# Altium schematic sheet ConstantLED.SchDoc(ConstantLED)
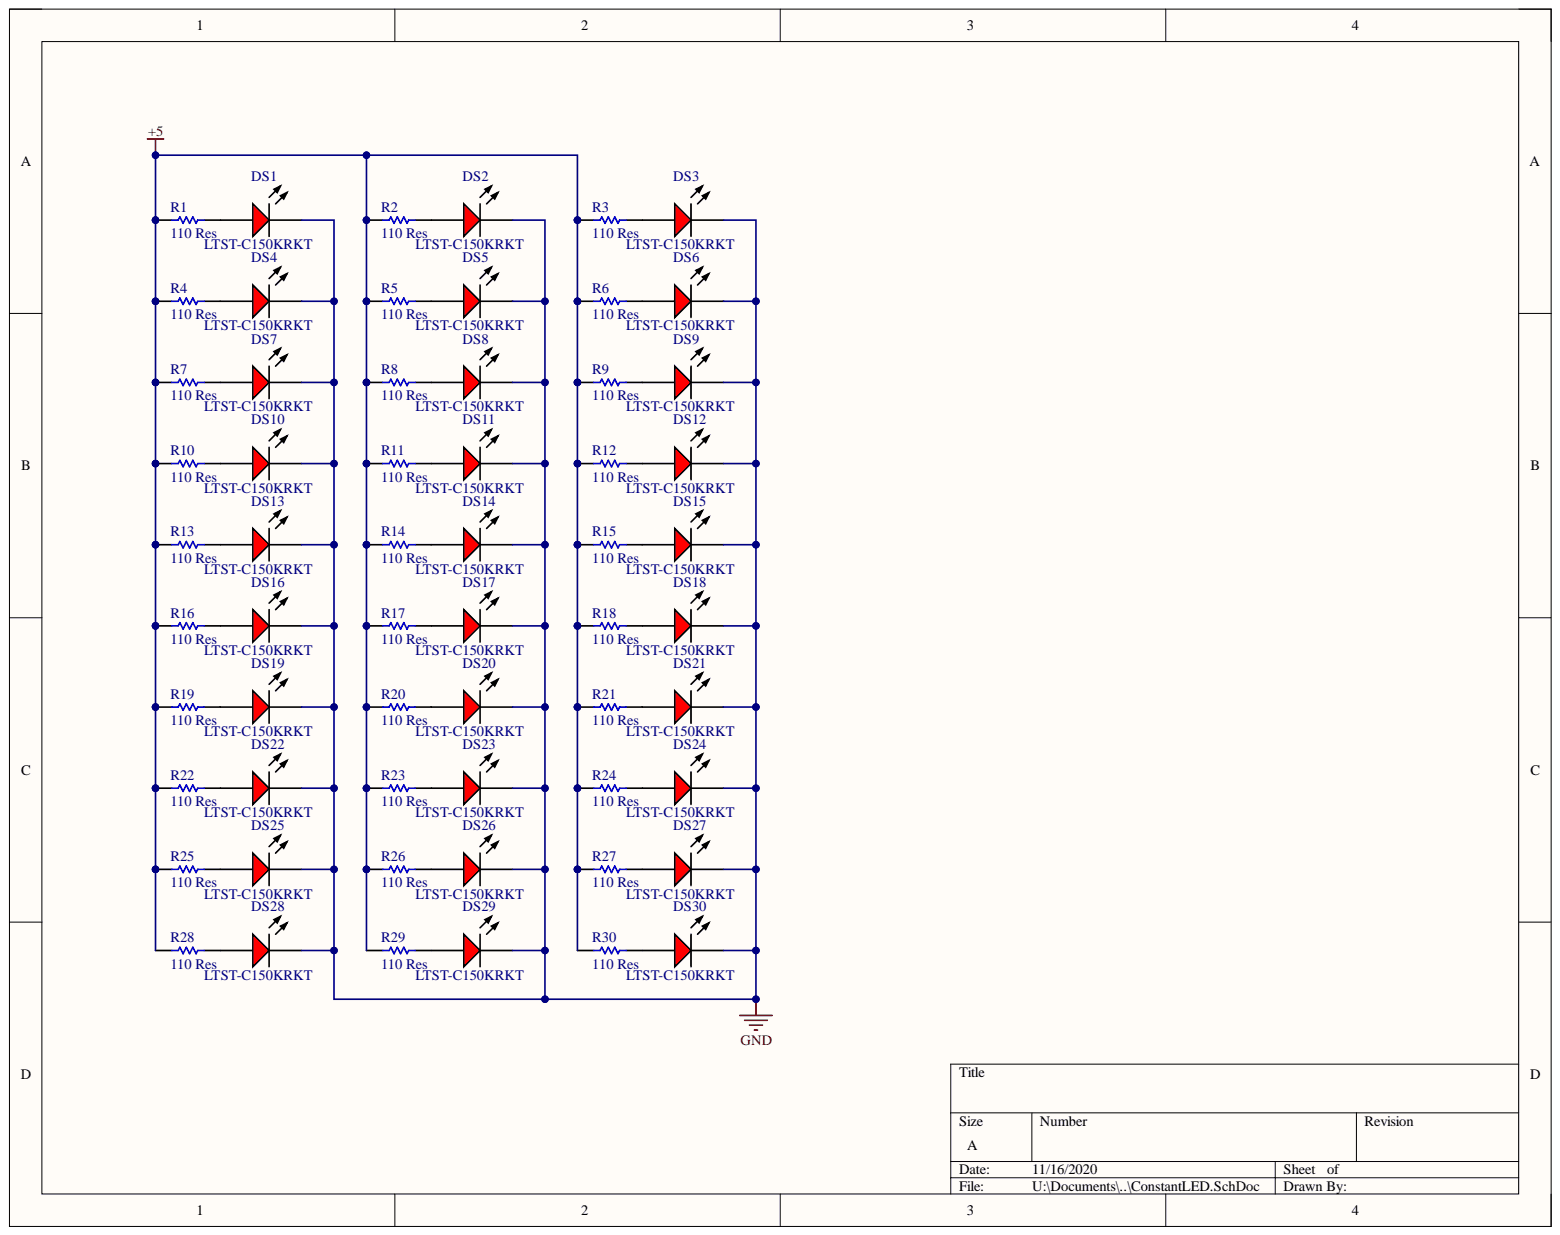
[diagram p0: ConstantLED.SchDoc]

## Parts
DS1  LTST-C150KRKT  [LTST-C150KRKT_V]
DS2  LTST-C150KRKT  [LTST-C150KRKT_V]
DS3  LTST-C150KRKT  [LTST-C150KRKT_V]
DS4  LTST-C150KRKT  [LTST-C150KRKT_V]
DS5  LTST-C150KRKT  [LTST-C150KRKT_V]
DS6  LTST-C150KRKT  [LTST-C150KRKT_V]
DS7  LTST-C150KRKT  [LTST-C150KRKT_V]
DS8  LTST-C150KRKT  [LTST-C150KRKT_V]
DS9  LTST-C150KRKT  [LTST-C150KRKT_V]
DS10  LTST-C150KRKT  [LTST-C150KRKT_V]
DS11  LTST-C150KRKT  [LTST-C150KRKT_V]
DS12  LTST-C150KRKT  [LTST-C150KRKT_V]
DS13  LTST-C150KRKT  [LTST-C150KRKT_V]
DS14  LTST-C150KRKT  [LTST-C150KRKT_V]
DS15  LTST-C150KRKT  [LTST-C150KRKT_V]
DS16  LTST-C150KRKT  [LTST-C150KRKT_V]
DS17  LTST-C150KRKT  [LTST-C150KRKT_V]
DS18  LTST-C150KRKT  [LTST-C150KRKT_V]
DS19  LTST-C150KRKT  [LTST-C150KRKT_V]
DS20  LTST-C150KRKT  [LTST-C150KRKT_V]
DS21  LTST-C150KRKT  [LTST-C150KRKT_V]
DS22  LTST-C150KRKT  [LTST-C150KRKT_V]
DS23  LTST-C150KRKT  [LTST-C150KRKT_V]
DS24  LTST-C150KRKT  [LTST-C150KRKT_V]
DS25  LTST-C150KRKT  [LTST-C150KRKT_V]
DS26  LTST-C150KRKT  [LTST-C150KRKT_V]
DS27  LTST-C150KRKT  [LTST-C150KRKT_V]
DS28  LTST-C150KRKT  [LTST-C150KRKT_V]
DS29  LTST-C150KRKT  [LTST-C150KRKT_V]
DS30  LTST-C150KRKT  [LTST-C150KRKT_V]
R1  110 Res  [RESC3216X70X50LL05T20]
R2  110 Res  [RESC3216X70X50LL05T20]
R3  110 Res  [RESC3216X70X50LL05T20]
R4  110 Res  [RESC3216X70X50LL05T20]
R5  110 Res  [RESC3216X70X50LL05T20]
R6  110 Res  [RESC3216X70X50LL05T20]
R7  110 Res  [RESC3216X70X50LL05T20]
R8  110 Res  [RESC3216X70X50LL05T20]
R9  110 Res  [RESC3216X70X50LL05T20]
R10  110 Res  [RESC3216X70X50LL05T20]
R11  110 Res  [RESC3216X70X50LL05T20]
R12  110 Res  [RESC3216X70X50LL05T20]
R13  110 Res  [RESC3216X70X50LL05T20]
R14  110 Res  [RESC3216X70X50LL05T20]
R15  110 Res  [RESC3216X70X50LL05T20]
R16  110 Res  [RESC3216X70X50LL05T20]
R17  110 Res  [RESC3216X70X50LL05T20]
R18  110 Res  [RESC3216X70X50LL05T20]
R19  110 Res  [RESC3216X70X50LL05T20]
R20  110 Res  [RESC3216X70X50LL05T20]
R21  110 Res  [RESC3216X70X50LL05T20]
R22  110 Res  [RESC3216X70X50LL05T20]
R23  110 Res  [RESC3216X70X50LL05T20]
R24  110 Res  [RESC3216X70X50LL05T20]
R25  110 Res  [RESC3216X70X50LL05T20]
R26  110 Res  [RESC3216X70X50LL05T20]
R27  110 Res  [RESC3216X70X50LL05T20]
R28  110 Res  [RESC3216X70X50LL05T20]
R29  110 Res  [RESC3216X70X50LL05T20]
R30  110 Res  [RESC3216X70X50LL05T20]

## Nets
+5: R1.1 R2.1 R3.1 R4.1 R5.1 R6.1 R7.1 R8.1 R9.1 R10.1 R11.1 R12.1 R13.1 R14.1 R15.1 R16.1 R17.1 R18.1 R19.1 R20.1 R21.1 R22.1 R23.1 R24.1 R25.1 R26.1 R27.1 R28.1 R29.1 R30.1
GND: DS1.2 DS2.2 DS3.2 DS4.2 DS5.2 DS6.2 DS7.2 DS8.2 DS9.2 DS10.2 DS11.2 DS12.2 DS13.2 DS14.2 DS15.2 DS16.2 DS17.2 DS18.2 DS19.2 DS20.2 DS21.2 DS22.2 DS23.2 DS24.2 DS25.2 DS26.2 DS27.2 DS28.2 DS29.2 DS30.2
NetDS1_1: DS1.1 R1.2
NetDS2_1: DS2.1 R2.2
NetDS3_1: DS3.1 R3.2
NetDS4_1: DS4.1 R4.2
NetDS5_1: DS5.1 R5.2
NetDS6_1: DS6.1 R6.2
NetDS7_1: DS7.1 R7.2
NetDS8_1: DS8.1 R8.2
NetDS9_1: DS9.1 R9.2
NetDS10_1: DS10.1 R10.2
NetDS11_1: DS11.1 R11.2
NetDS12_1: DS12.1 R12.2
NetDS13_1: DS13.1 R13.2
NetDS14_1: DS14.1 R14.2
NetDS15_1: DS15.1 R15.2
NetDS16_1: DS16.1 R16.2
NetDS17_1: DS17.1 R17.2
NetDS18_1: DS18.1 R18.2
NetDS19_1: DS19.1 R19.2
NetDS20_1: DS20.1 R20.2
NetDS21_1: DS21.1 R21.2
NetDS22_1: DS22.1 R22.2
NetDS23_1: DS23.1 R23.2
NetDS24_1: DS24.1 R24.2
NetDS25_1: DS25.1 R25.2
NetDS26_1: DS26.1 R26.2
NetDS27_1: DS27.1 R27.2
NetDS28_1: DS28.1 R28.2
NetDS29_1: DS29.1 R29.2
NetDS30_1: DS30.1 R30.2

## Library data of the parts
DS1-DS30 = CMP-2000-06617-1: LED Red, 62.5 mW, 50 mA, -30 to 85 degC, 2-Pin SMD, RoHS, Tape and Reel; Manufacturer: Lite-On; Chip Material: AlGaInP; DELETED: Compliant; Dominant Wave Length: 631nm; Forward Current: 30mA; Forward Voltage: 2.4V; High Brightness: Yes; Lum Intensity: 54mcd; Main Category: ChipLED; Number of  Elements: 1; Peak Wavelength: 639nm; Power Dissipation: 75W; Product Depth (mm): 1.6mm; Product Height (mm): 1.1mm; Product Length (mm): 3.2mm; Rad Hardened: No; Reverse Voltage: 5V; Shape Type: Rectangular; Test Current (It): 20mA; Type: Uni-Color; Viewing Angle: 130deg; Component Kind: Standard; Datasheet URL: http://optoelectronics.liteon.com/upload/download/DS-22-99-0149/S_110_LTST-C150KRKT.pdf; Datasheet Version: Rev. C, 01/2013; Emitting Color: Red; Lens Dimensions: 2.06X1.6X0.6mm; Library Name: Altium Content Vault; Luminous Intensity: 54mcd; Manufacturer URL: http://www.us.liteon.com/; Mounting: SurfaceMount; Mounting Technology: SurfaceMount; Operating Temp Range: -55Cto85C; Operating Temperature Classification: Industrial; Package Description: 2-Pin Surface Mount Device, Body 3.2 x 1.6 mm; Package Reference: C150KRKT; Package Type: ChipLed; Packaging: TapeandReel; Pin Count: 2
R1-R30 = CMP-2003-00040-1: Manufacturer: Panasonic; Part Number: ERJ-8ENF1100V; Component Kind: Standard; Library Name: Altium Content Vault
